# Altium schematic sheet Top.SchDoc
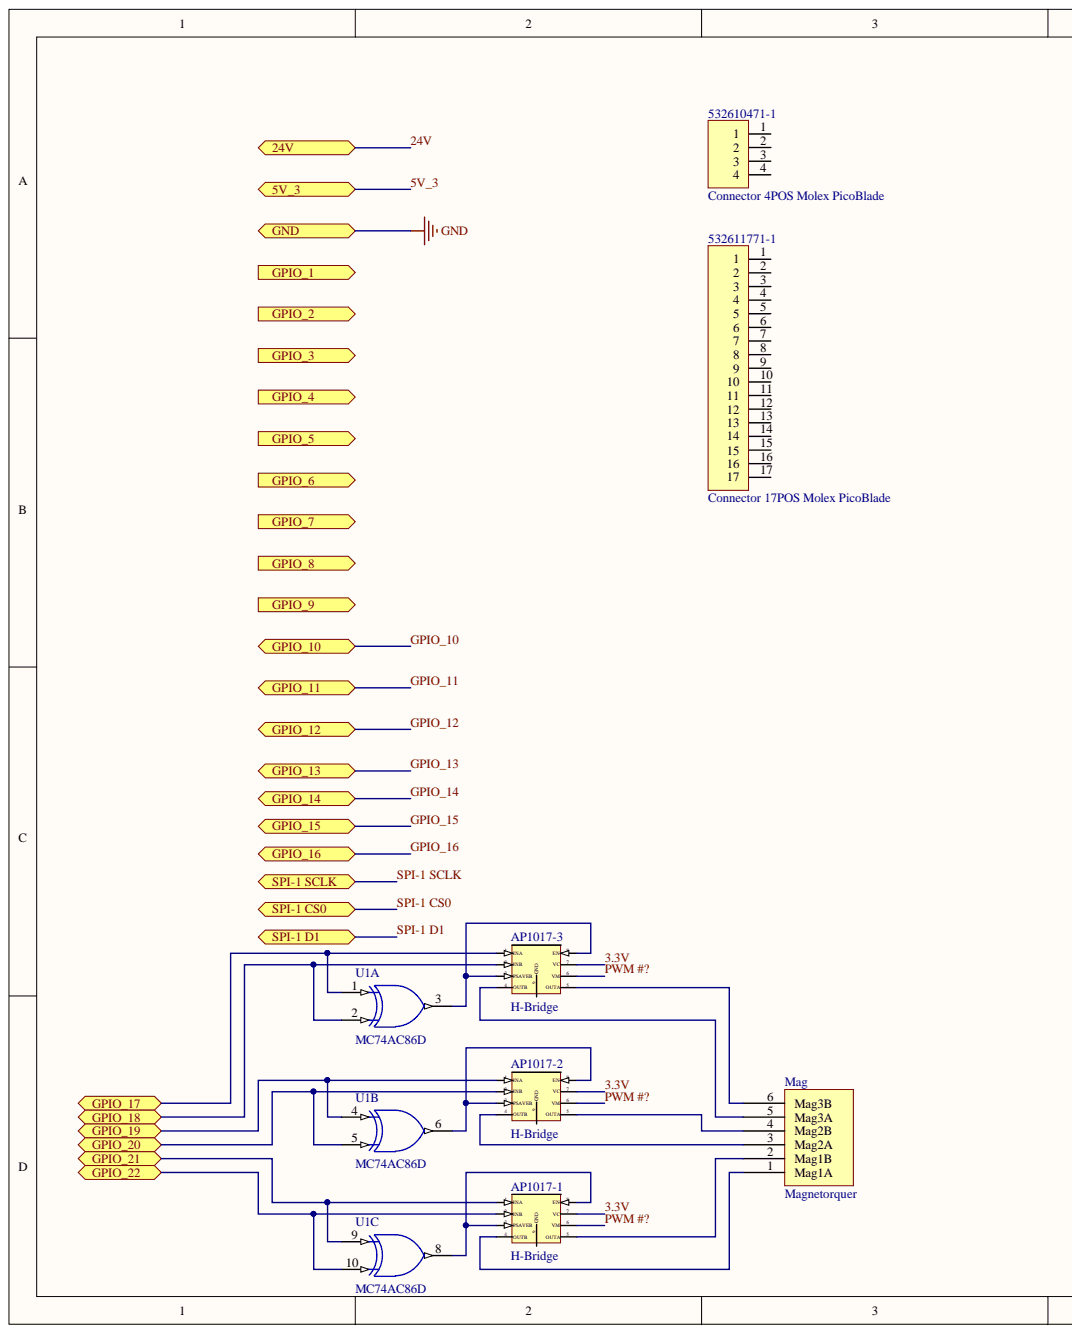
[diagram p0: Top.SchDoc, left]
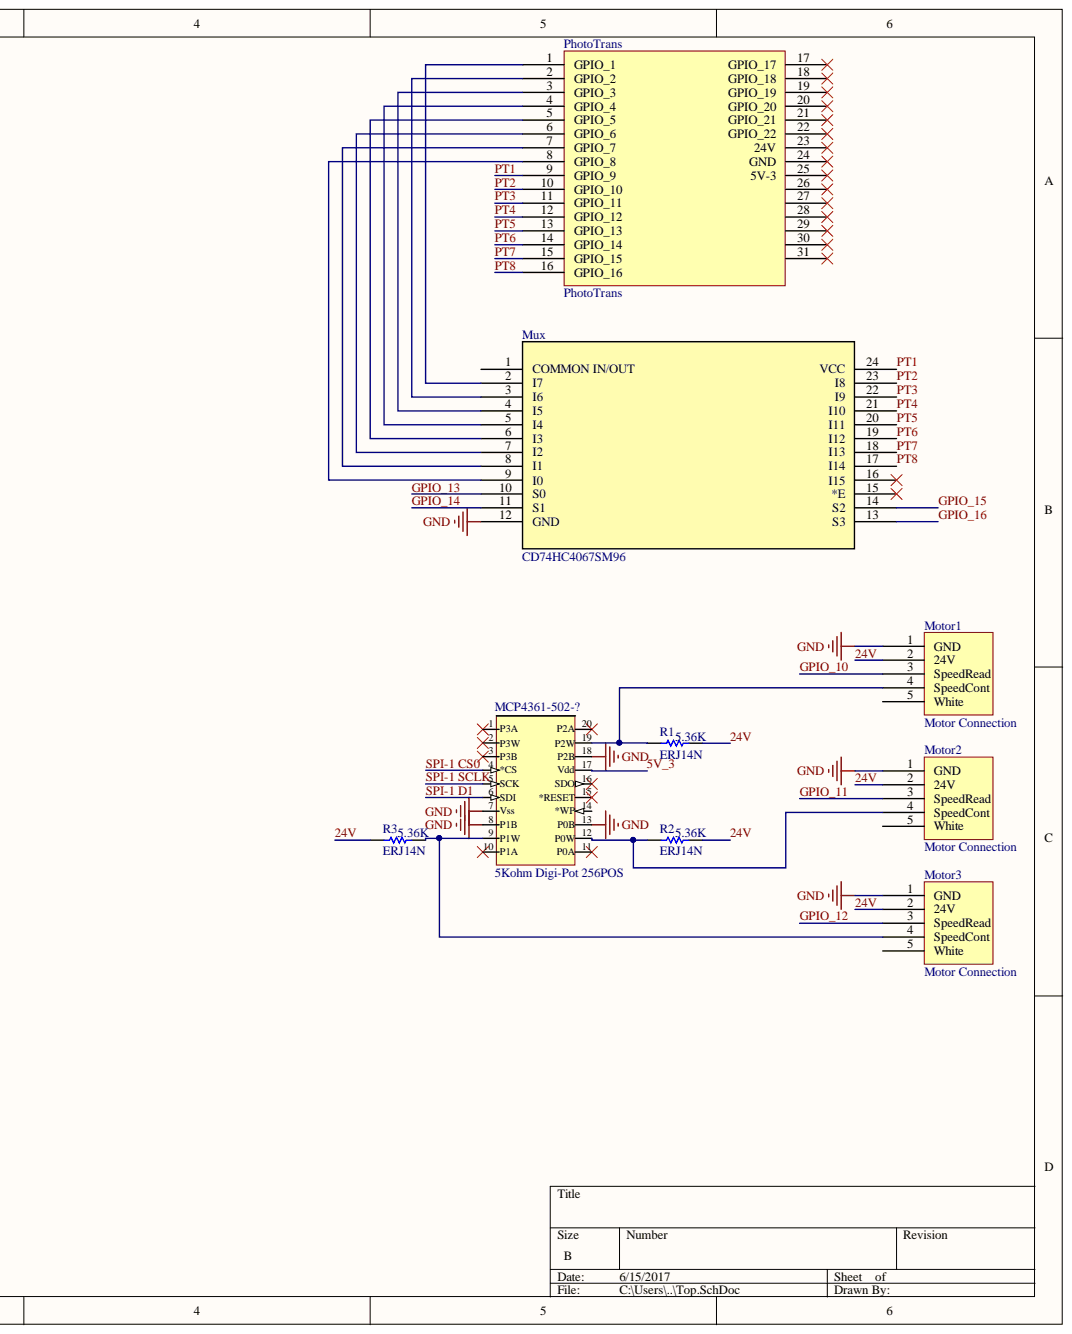
[diagram p1: Top.SchDoc, right]

## Parts
532610471-1  Connector 4POS Molex PicoBlade
532611771-1  Connector 17POS Molex PicoBlade
AP1017-1  H-Bridge  [SON50P200X60_HS-9N]
AP1017-2  H-Bridge  [SON50P200X60_HS-9N]
AP1017-3  H-Bridge  [SON50P200X60_HS-9N]
Mag  Magnetorquer  [Pressure Connector]
MCP4361-502-?  5Kohm Digi-Pot 256POS  [MCP4361-502]
Motor1  Motor Connection  [Molex Picoblade 5 Pin]
Motor2  Motor Connection  [Molex Picoblade 5 Pin]
Motor3  Motor Connection  [Molex Picoblade 5 Pin]
Mux  CD74HC4067SM96  [DB24]
PhotoTrans  PhotoTrans  [ADCS2Umb]
R1  ERJ14N  Resistance: 5.36K  [14-1210]
R2  ERJ14N  Resistance: 5.36K  [14-1210]
R3  ERJ14N  Resistance: 5.36K  [14-1210]
U1  MC74AC86D  [751A-03_N]  (parts A,B,C)

## Ports
5V_3, 24V, GND, GPIO_1, GPIO_2, GPIO_3, GPIO_4, GPIO_5, GPIO_6, GPIO_7, GPIO_8, GPIO_9, GPIO_10, GPIO_11, GPIO_12, GPIO_13, GPIO_14, GPIO_15, GPIO_16, GPIO_17, GPIO_18, GPIO_19, GPIO_20, GPIO_21, GPIO_22, SPI-1 CS0, SPI-1 D1, SPI-1 SCLK

## Nets
VDD: U1.14
3.3V: AP1017-1.7 AP1017-2.7 AP1017-3.7
5V_3: MCP4361-502-?.17(Vdd) ; port 5V_3
24V: Motor1.2(24V) Motor2.2(24V) Motor3.2(24V) R1.2 R2.2 R3.1 ; port 24V
GND: MCP4361-502-?.7(Vss) MCP4361-502-?.8(P1B) MCP4361-502-?.13(P0B) MCP4361-502-?.18(P2B) Motor1.1(GND) Motor2.1(GND) Motor3.1(GND) Mux.12(GND) U1.7 ; port GND
GPIO_10: Motor1.3(SpeedRead) ; port GPIO_10
GPIO_11: Motor2.3(SpeedRead) ; port GPIO_11
GPIO_12: Motor3.3(SpeedRead) ; port GPIO_12
GPIO_13: Mux.10(S0) ; port GPIO_13
GPIO_14: Mux.11(S1) ; port GPIO_14
GPIO_15: Mux.14(S2) ; port GPIO_15
GPIO_16: Mux.13(S3) ; port GPIO_16
GPIO_17: AP1017-3.1(INA) U1.1 ; port GPIO_17
GPIO_18: AP1017-3.2(INB) U1.2 ; port GPIO_18
GPIO_19: AP1017-2.1(INA) U1.4 ; port GPIO_19
GPIO_20: AP1017-2.2(INB) U1.5 ; port GPIO_20
GPIO_21: AP1017-1.1(INA) U1.9 ; port GPIO_21
GPIO_22: AP1017-1.2(INB) U1.10 ; port GPIO_22
PT1: Mux.24(VCC) PhotoTrans.9(GPIO_9)
PT2: Mux.23(I8) PhotoTrans.10(GPIO_10)
PT3: Mux.22(I9) PhotoTrans.11(GPIO_11)
PT4: Mux.21(I10) PhotoTrans.12(GPIO_12)
PT5: Mux.20(I11) PhotoTrans.13(GPIO_13)
PT6: Mux.19(I12) PhotoTrans.14(GPIO_14)
PT7: Mux.18(I13) PhotoTrans.15(GPIO_15)
PT8: Mux.17(I14) PhotoTrans.16(GPIO_16)
PWM #?: AP1017-1.6 AP1017-2.6 AP1017-3.6
SPI-1 CS0: MCP4361-502-?.4(*CS) ; port SPI-1 CS0
SPI-1 D1: MCP4361-502-?.6(SDI) ; port SPI-1 D1
SPI-1 SCLK: MCP4361-502-?.5(SCK) ; port SPI-1 SCLK
NetAP1017-1_3: AP1017-1.3(PSAVEB) AP1017-1.8 U1.8
NetAP1017-1_4: AP1017-1.4(OUTB) Mag.1(Mag1A)
NetAP1017-1_5: AP1017-1.5 Mag.2(Mag1B)
NetAP1017-2_3: AP1017-2.3(PSAVEB) AP1017-2.8 U1.6
NetAP1017-2_4: AP1017-2.4(OUTB) Mag.3(Mag2A)
NetAP1017-2_5: AP1017-2.5 Mag.4(Mag2B)
NetAP1017-3_3: AP1017-3.3(PSAVEB) AP1017-3.8 U1.3
NetAP1017-3_4: AP1017-3.4(OUTB) Mag.5(Mag3A)
NetAP1017-3_5: AP1017-3.5 Mag.6(Mag3B)
NetMCP4361-502-?_9: MCP4361-502-?.9(P1W) Motor3.4(SpeedCont) R3.2
NetMCP4361-502-?_12: MCP4361-502-?.12(P0W) Motor2.4(SpeedCont) R2.1
NetMCP4361-502-?_19: MCP4361-502-?.19(P2W) Motor1.4(SpeedCont) R1.1
NetMux_2: Mux.2(I7) PhotoTrans.1(GPIO_1)
NetMux_3: Mux.3(I6) PhotoTrans.2(GPIO_2)
NetMux_4: Mux.4(I5) PhotoTrans.3(GPIO_3)
NetMux_5: Mux.5(I4) PhotoTrans.4(GPIO_4)
NetMux_6: Mux.6(I3) PhotoTrans.5(GPIO_5)
NetMux_7: Mux.7(I2) PhotoTrans.6(GPIO_6)
NetMux_8: Mux.8(I1) PhotoTrans.7(GPIO_7)
NetMux_9: Mux.9(I0) PhotoTrans.8(GPIO_8)
N00013: ; port GPIO_7
N00014: ; port GPIO_6
N00015: ; port GPIO_4
N00016: ; port GPIO_3
N00017: ; port GPIO_9
N00018: ; port GPIO_8
N00019: ; port GPIO_5
N00020: ; port GPIO_2
N00021: ; port GPIO_1

Not connected: 532610471-1 1 2 3 4; 532611771-1 1 2 3 4 5 6 7 8 9 10 11 12 13 14 15 16 17; AP1017-1 9(GND); AP1017-2 9(GND); AP1017-3 9(GND); MCP4361-502-? 1(P3A) 2(P3W) 3(P3B) 10(P1A) 11(P0A) 14(*WP) 15(*RESET) 16(SDO) 20(P2A); Motor1 5(White); Motor2 5(White); Motor3 5(White); Mux 1(COMMON_IN/OUT) 15(*E) 16(I15); PhotoTrans 17(GPIO_17) 18(GPIO_18) 19(GPIO_19) 20(GPIO_20) 21(GPIO_21) 22(GPIO_22) 23(24V) 24(GND) 25(5V-3) 26 27 28 29 30 31

## Library data of the parts
532610471-1 = 532610471: Component Kind: Standard; Description: Connector 4POS Molex PicoBlade; Library Name: SchematicComponents.SchLib
532611771-1 = 532611771: Component Kind: Standard; Description: Connector 17POS Molex PicoBlade; Library Name: SchematicComponents.SchLib
AP1017-1-AP1017-3 = AP1017AEN: Component Kind: Standard; Description: H-Bridge; Library Name: SchematicComponents.SchLib
Mag = PressureConnector: Molex 70553-0005; Component Kind: Standard; Library Name: UmbilicalBoardV2.SCHLIB
MCP4361-502-? = MCP4361-502: Component Kind: Standard; Description: 5Kohm Digi-Pot 256POS; Library Name: SchematicComponents.SchLib
Motor1-Motor3 = PIKSI_UART Connector: Molex Picoblade 5 Pin; Component Kind: Standard; Library Name: UmbilicalBoardV2.SCHLIB
Mux = CD74HC4067SM96: Imported; Manufacturer_Name: Texas Instruments; Manufacturer_Part_Number: CD74HC4067SM96E4; 3D_Library_Name: TI_Delivered_11-28-2011; C: Copyright (C) 2015 Accelerated Designs. All rights reserved; Section: Switches and Multiplexers; Component Kind: Standard; Family: Analogue Switch Multiplexer; Library Name: AltiumDesigner.SchLib; RefDes: RefDes; RS_Part_Number: 6622570; Type: Type; Value: Value; Vendor: Texas Instruments; Copyright: Copyright (C) 2015 Accelerated Designs. All rights reserved
PhotoTrans = ADCS2Umb: Part Number: 501571-4007; Component Kind: Standard
R1-R3 = ERJ14N: Precision Thick Film Chip Resistor, 10 Ohm to 1M Ohm Range, 1% Tolerance, 1210 Size, 0.25 W; Code_IPC: 3225 [1210]; Component Kind: Standard; ComponentLink1Description: Manufacturer Link; ComponentLink1URL: http://www.panasonic.com/industrial/components/resistive_products.htm; ComponentLink2Description: Datasheet; ComponentLink2URL: http://www.panasonic.com/industrial/components/pdf/AOA0000CE2.pdf; LatestRevisionDate: 19-Mar-2004; LatestRevisionNote: Silkscreen size reduced.; Library Name: Panasonic Resistor.IntLib; PackageDocument: Mar-2003; PackageReference: 14-1210; Published: 17-Dec-2003; Signal Integrity: Res; Simulation: RESISTOR; Publisher: Altium Limited
U1 = MC74AC86D: Quad 2-Input XOR Gate; Code_IPC: SOIC127P600-14; Code_JEDEC: MS-012-AB; Component Kind: Standard; ComponentLink1Description: Manufacturer Link; ComponentLink1URL: http://www.onsemi.com/; ComponentLink2Description: Datasheet; ComponentLink2URL: http://www.onsemi.com/pub/Collateral/MC74AC86-D.PDF; DatasheetVersion: 9-Apr-1996; LatestRevisionDate: 13-Apr-2006; LatestRevisionNote: IPC-7351 Footprint Added.; Library Name: ON Semi Logic Gate.IntLib; PackageDescription: 14-Pin Small Outline Integrated Circuit 1.27 mm Pitch; PackageReference: 751A-03; Published: 15-Apr-2002; Signal Integrity: MC74AC86D; Publisher: Altium Limited
